# Altium schematic sheet busanalizer.SchDoc
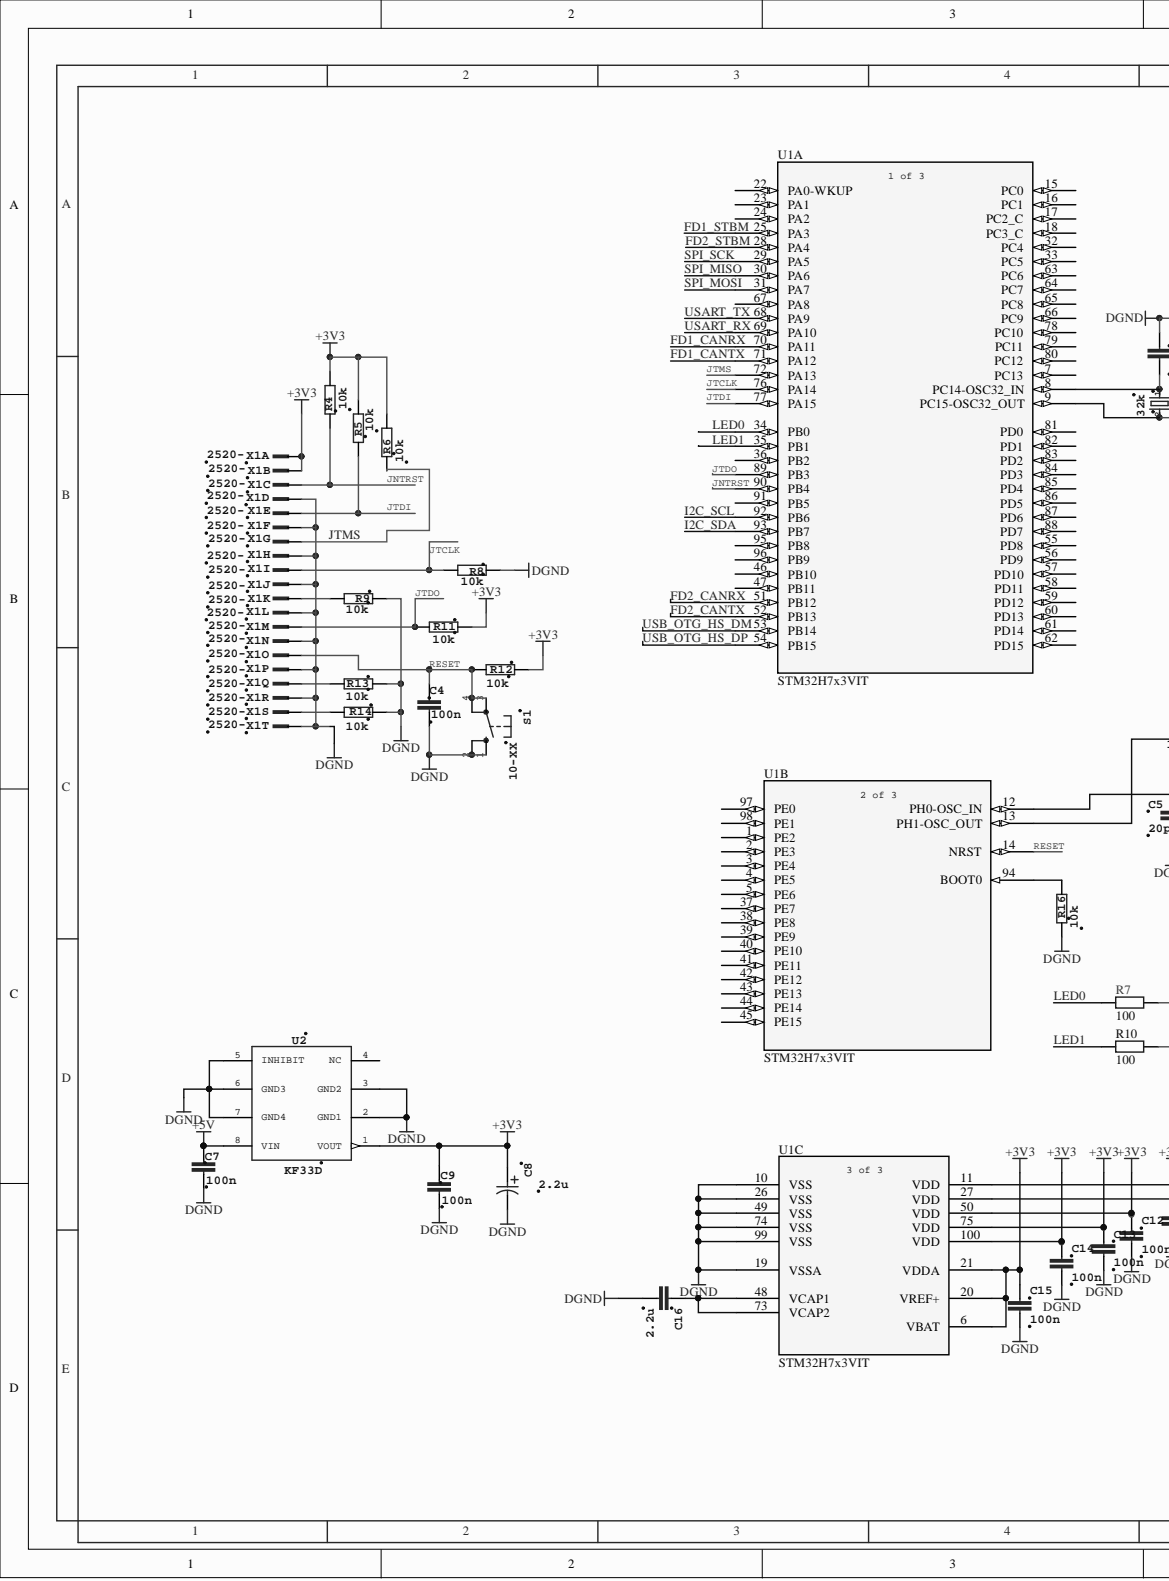
[diagram p0: busanalizer.SchDoc, left]
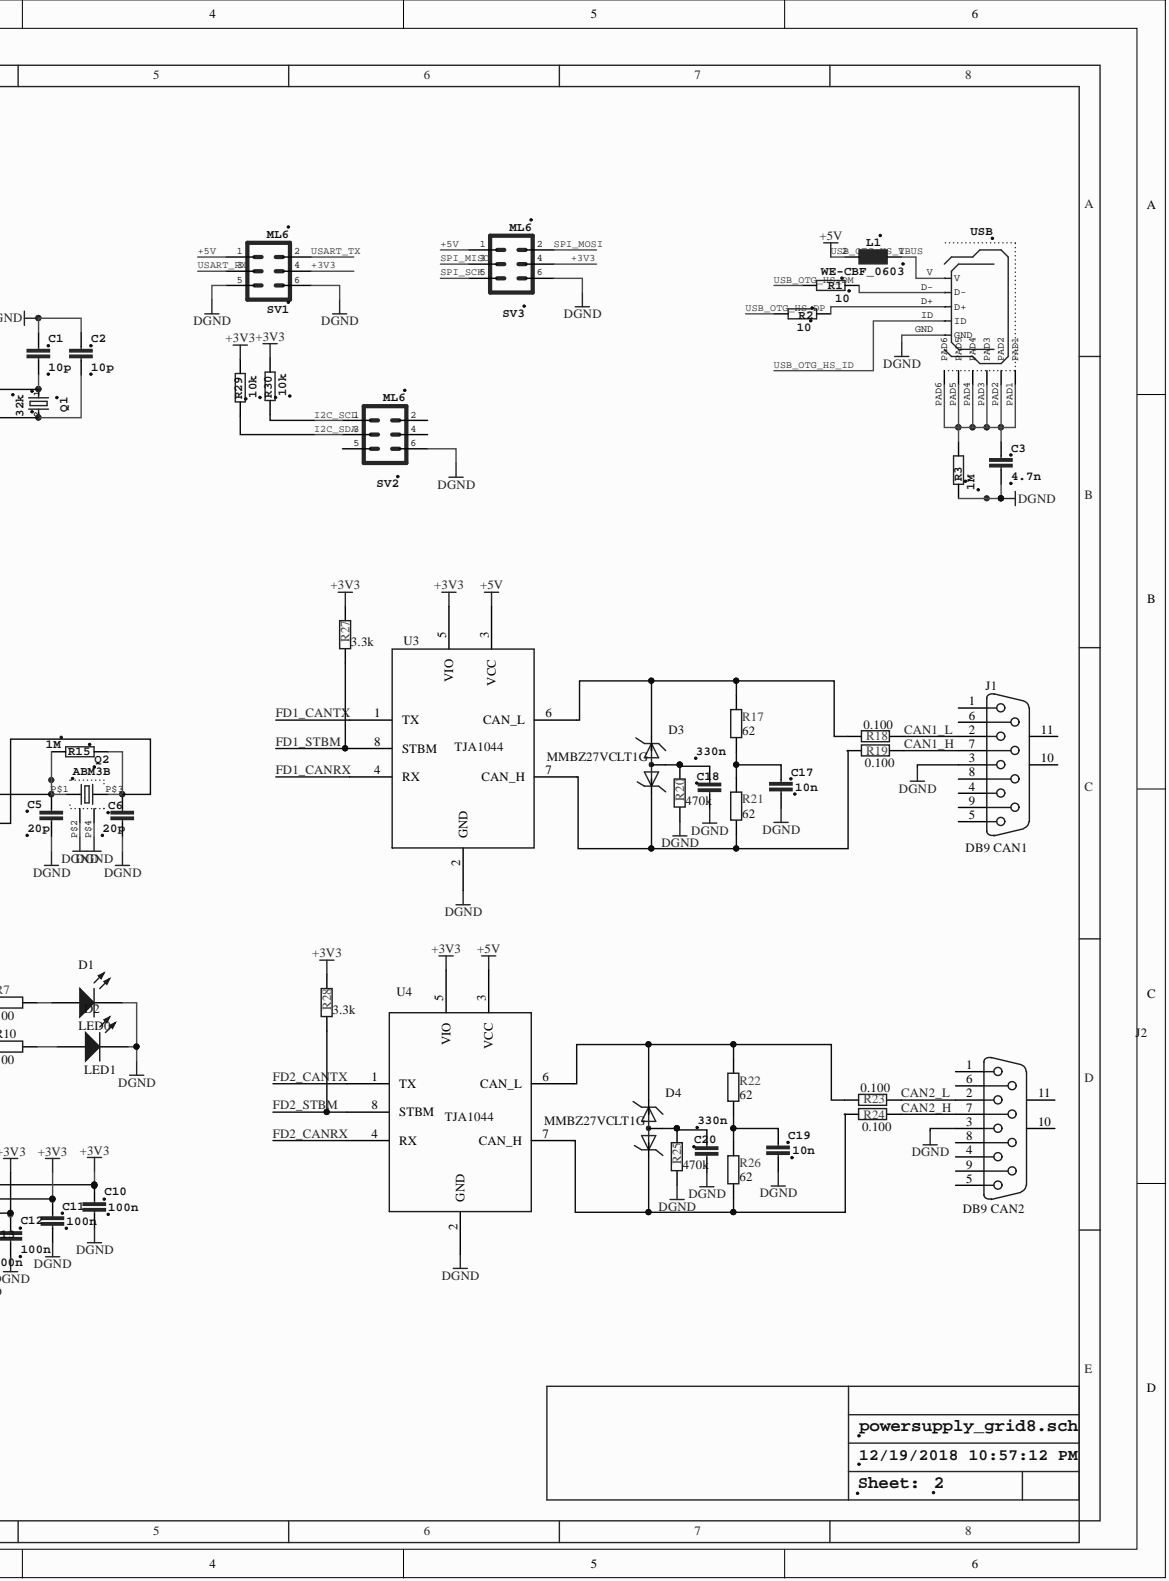
[diagram p1: busanalizer.SchDoc, right]

## Parts
C1  10p  [C0402]
C2  10p  [C0402]
C3  4.7n  [C0805]
C4  100n  [C0402]
C5  20p  [C0402]
C6  20p  [C0402]
C7  100n  [C0603]
C8  2.2u  [CAPAE430X610N]
C9  100n  [C0603]
C10  100n  [C0402]
C11  100n  [C0402]
C12  100n  [C0402]
C13  100n  [C0402]
C14  100n  [C0402]
C15  100n  [C0402]
C16  2.2u  [C0805]
C17  10n  [0603]
C18  330n  [0603]
C19  10n  [0603]
C20  330n  [0603]
D1  LED0  [LED-0]
D2  LED1  [LED-0]
D3  MMBZ27VCLT1G  [SOT23]
D4  MMBZ27VCLT1G  [SOT23]
J1  DB9 CAN1  [DSUB1.385-2H9]
J2  DB9 CAN2  [DSUB1.385-2H9]
L1  WE-CBF_0603  [0603]
Q1  32k  [TC26V]
Q2  ABM3B  [ABM3B]
R1  10  [R0805]
R2  10  [R0805]
R3  1M  [R0805]
R4  10k  [R0805]
R5  10k  [R0805]
R6  10k  [R0805]
R7  value 100  [6-0805_L]  (hidden comment: Res2)
R8  10k  [R0805]
R9  10k  [R0805]
R10  value 100  [6-0805_L]  (hidden comment: Res2)
R11  10k  [R0805]
R12  10k  [R0805]
R13  10k  [R0805]
R14  10k  [R0805]
R15  1M  [R0402]
R16  10k  [R0805]
R17  value 62  [6-0805_L]  (hidden comment: Res2)
R18  value 0.100  [6-0805_L]  (hidden comment: Res2)
R19  value 0.100  [6-0805_L]  (hidden comment: Res2)
R20  value 470k  [6-0805_L]  (hidden comment: Res2)
R21  value 62  [6-0805_L]  (hidden comment: Res2)
R22  value 62  [6-0805_L]  (hidden comment: Res2)
R23  value 0.100  [6-0805_L]  (hidden comment: Res2)
R24  value 0.100  [6-0805_L]  (hidden comment: Res2)
R25  value 470k  [6-0805_L]  (hidden comment: Res2)
R26  value 62  [6-0805_L]  (hidden comment: Res2)
R27  value 3.3k  [6-0805_L]  (hidden comment: Res2)
R28  value 3.3k  [6-0805_L]  (hidden comment: Res2)
R29  10k  [R0805]
R30  10k  [R0805]
S1  10-XX  [B3F-10XX]
SV1  ML6  [ML6]
SV2  ML6  [ML6]
SV3  ML6  [ML6]
U1  STM32H7x3VIT  [LQFP100-14x14mm]  (parts A,B,C)
U2  KF33D  [SO8]
U3  TJA1044  [SO8]
U4  TJA1044  [SO8]
USB  USB_MICROB  [USB_MICROB_PACKAGE]  (value not drawn)
X1  2520-  [PAK100/2500-20]  (parts A,B,C,D,E,F,G,H,I,J,K,L,M,N,O,P,Q,R,S,T)

## Nets
DGND: C1.1 C2.1 C3.2 C4.2 C5.2 C6.2 C7.2 C8.2 C9.2 C10.2 C11.2 C12.2 C13.2 C14.2 C15.2 C16.2 C17.2 C18.2 C19.2 C20.2 D1.2 D2.2 J1.3 J2.3 Q2.P$2 Q2.P$4 R3.1 R8.1 R9.1 R13.1 R14.1 R16.1 R20.1 R25.1 S1.1 S1.2 SV1.5 SV1.6 SV2.6 SV3.6 U1.10(VSS) U1.19(VSSA) U1.26(VSS) U1.49(VSS) U1.74(VSS) U1.99(VSS) U2.2(GND1) U2.3(GND2) U2.5(INHIBIT) U2.6(GND3) U2.7(GND4) U3.2(GND) U4.2(GND) USB.GND X1.4 X1.6 X1.8 X1.10 X1.12 X1.14 X1.16 X1.18 X1.20
+3V3: C8.1 C9.1 C10.1 C11.1 C12.1 C13.1 C14.1 C15.1 R4.2 R5.2 R6.2 R11.1 R12.1 R27.2 R28.2 R29.2 R30.2 SV1.4 SV3.4 U1.6(VBAT) U1.11(VDD) U1.20(VREF+) U1.21(VDDA) U1.27(VDD) U1.50(VDD) U1.75(VDD) U1.100(VDD) U2.1(VOUT) U3.5(VIO) U4.5(VIO) X1.1 X1.2
+5V: C7.1 L1.2 SV1.1 SV3.1 U2.8(VIN) U3.3(VCC) U4.3(VCC)
CAN1_H: J1.7 R19.2
CAN1_L: J1.2 R18.2
CAN2_H: J2.7 R24.2
CAN2_L: J2.2 R23.2
FD1_CANRX: U1.70(PA11) U3.4(RX)
FD1_CANTX: U1.71(PA12) U3.1(TX)
FD1_STBM: R27.1 U1.25(PA3) U3.8(STBM)
FD2_CANRX: U1.51(PB12) U4.4(RX)
FD2_CANTX: U1.52(PB13) U4.1(TX)
FD2_STBM: R28.1 U1.28(PA4) U4.8(STBM)
I2C_SCL: R30.1 SV2.1 U1.92(PB6)
I2C_SDA: R29.1 SV2.3 U1.93(PB7)
JNTRST: R4.1 U1.90(PB4) X1.3
JTCLK: R8.2 U1.76(PA14) X1.9
JTDI: R5.1 U1.77(PA15) X1.5
JTDO: R11.2 U1.89(PB3) X1.13
JTMS: R6.1 U1.72(PA13) X1.7
LED0: R7.1 U1.34(PB0)
LED1: R10.1 U1.35(PB1)
RESET: C4.1 R12.2 S1.3 S1.4 U1.14(NRST) X1.15
SPI_MISO: SV3.3 U1.30(PA6)
SPI_MOSI: SV3.2 U1.31(PA7)
SPI_SCK: SV3.5 U1.29(PA5)
USART_RX: SV1.3 U1.69(PA10)
USART_TX: SV1.2 U1.68(PA9)
USB_OTG_HS_DM: R1.2 U1.53(PB14)
USB_OTG_HS_DP: R2.2 U1.54(PB15)
USB_OTG_HS_ID: USB.ID
NetC1_2: C1.2 Q1.1 U1.8(PC14-OSC32_IN)
NetC2_2: C2.2 Q1.2 U1.9(PC15-OSC32_OUT)
NetC3_1: C3.1 R3.2 USB.PAD1 USB.PAD2 USB.PAD3 USB.PAD4 USB.PAD5 USB.PAD6
NetC5_1: C5.1 Q2.P$1 R15.2 U1.12(PH0-OSC_IN)
NetC6_1: C6.1 Q2.P$3 R15.1 U1.13(PH1-OSC_OUT)
NetC16_1: C16.1 U1.48(VCAP1) U1.73(VCAP2)
NetC17_1: C17.1 R17.1 R21.2
NetC18_1: C18.1 D3.3 R20.2
NetC19_1: C19.1 R22.1 R26.2
NetC20_1: C20.1 D4.3 R25.2
NetD1_1: D1.1 R7.2
NetD2_1: D2.1 R10.2
NetD3_1: D3.1 R17.2 R18.1 U3.6(CAN_L)
NetD3_2: D3.2 R19.1 R21.1 U3.7(CAN_H)
NetD4_1: D4.1 R22.2 R23.1 U4.6(CAN_L)
NetD4_2: D4.2 R24.1 R26.1 U4.7(CAN_H)
NetL1_1: L1.1 USB.V
NetR1_1: R1.1 USB.D-
NetR2_1: R2.1 USB.D+
NetR9_2: R9.2 X1.11
NetR13_2: R13.2 X1.17
NetR14_2: R14.2 X1.19
NetR16_2: R16.2 U1.94(BOOT0)

Not connected: J1 1 4 5 6 8 9 10 11; J2 1 4 5 6 8 9 10 11; SV2 2 4 5; U1 1(PE2) 2(PE3) 3(PE4) 4(PE5) 5(PE6) 7(PC13) 15(PC0) 16(PC1) 17(PC2_C) 18(PC3_C) 22(PA0-WKUP) 23(PA1) 24(PA2) 32(PC4) 33(PC5) 36(PB2) 37(PE7) 38(PE8) 39(PE9) 40(PE10) 41(PE11) 42(PE12) 43(PE13) 44(PE14) 45(PE15) 46(PB10) 47(PB11) 55(PD8) 56(PD9) 57(PD10) 58(PD11) 59(PD12) 60(PD13) 61(PD14) 62(PD15) 63(PC6) 64(PC7) 65(PC8) 66(PC9) 67(PA8) 78(PC10) 79(PC11) 80(PC12) 81(PD0) 82(PD1) 83(PD2) 84(PD3) 85(PD4) 86(PD5) 87(PD6) 88(PD7) 91(PB5) 95(PB8) 96(PB9) 97(PE0) 98(PE1); U2 4(NC)

## Library data of the parts
C1 C2 C4-C6 C10-C15 C17-C20 = C-EUC0402: <B>CAPACITOR</B>, European symbol; Component Kind: Standard; Library Name: rcl.IntLib; SPICEPREFIX: C
C3 = C-EUC0805: <B>CAPACITOR</B>, European symbol; Component Kind: Standard; Library Name: rcl.IntLib; SPICEPREFIX: C
C7 C9 = C-EUC0603: <B>CAPACITOR</B>, European symbol; Component Kind: Standard; Library Name: rcl.IntLib; SPICEPREFIX: C
C8 = EEE--CASE-B: MPN: EEE-HC1HR47R; MPN: EEE-HC1HR33R; MPN: EEE-HC1HR10R; MPN: EEE-HC1H3R3R; MPN: EEE-HC1H2R2R; MPN: EEE-HC1H1R0R; MPN: EEE-HC1C100R; MPN: EEE-HC0J220R; MPN: EEE-HB1H2R2R; MPN: EEE-HB1H1R0R; MPN: EEE-HB1E4R7R; MPN: EEE-HB1C100R; MPN: EEE-HB0J220R; MPN: EEE-HA1V4R7R; MPN: EEE-HA1V100WR; MPN: EEE-HA1HR47R; MPN: EEE-HA1HR33R; MPN: EEE-HA1HR22R; MPN: EEE-HA1HR10R; MPN: EEE-HA1H3R3R; MPN: EEE-HA1H2R2R; MPN: EEE-HA1H1R0R; MPN: EEE-HA1E4R7R; MPN: EEE-HA1E100WR; MPN: EEE-HA1C220WR; MPN: EEE-HA1C100R; MPN: EEE-HA1A330WR; MPN: EEE-HA1A220WR; MPN: EEE-HA0J470WR; MPN: EEE-HA0J330WR; MPN: EEE-HA0J220R; MPN: EEE-FTH100UAR; MPN: EEE-FT1V220AR; MPN: EEE-FT1E330AR; MPN: EEE-FT1E220AR; MPN: EEE-FT1C470AR; MPN: EEE-FT1A680AR; MPN: EEE-FT0J101AR; TOLERANCE: ±20 %; VOLTAGERATING: 50V; VOLTAGERATING: 16V; VOLTAGERATING: 6.3V; VOLTAGERATING: 25V; VOLTAGERATING: 35V; VOLTAGERATING: 10V; Component Kind: Standard; OC_FARNELL: 1714711; OC_FARNELL: 1714710; OC_FARNELL: 1714708; OC_FARNELL: 1714714; OC_FARNELL: 1714713; OC_FARNELL: 1714712; OC_FARNELL: 1714692; OC_FARNELL: 1714683; OC_FARNELL: 9696865; OC_FARNELL: 9696857; OC_FARNELL: 9696822; OC_FARNELL: 9696792; OC_FARNELL: 9696750; OC_FARNELL: 1973337; OC_FARNELL: 1973338; OC_FARNELL: 1973357; OC_FARNELL: 1973355; OC_FARNELL: 1973354; OC_FARNELL: 1973353; OC_FARNELL: 1973360; OC_FARNELL: 1973359; OC_FARNELL: 1973358; OC_FARNELL: 1973317; OC_FARNELL: 1973318; OC_FARNELL: 1973302; OC_FARNELL: 1973301; OC_FARNELL: 1973291; OC_FARNELL: 1973288; OC_FARNELL: 1973276; OC_FARNELL: 1973275; OC_FARNELL: 1973274; OC_FARNELL: 1868422; OC_FARNELL: 1868413; OC_FARNELL: 1868403; OC_FARNELL: 1868402; OC_FARNELL: 2065967; OC_FARNELL: 2065959; OC_FARNELL: 2065951; OC_NEWARK: 15R0920; OC_NEWARK: 15R0919; OC_NEWARK: 15R0917; OC_NEWARK: 15R0926; OC_NEWARK: 15R0924; OC_NEWARK: 15R0921; OC_NEWARK: 15R0908; OC_NEWARK: 15R0902; OC_NEWARK: 96K9421; OC_NEWARK: 96K9419; OC_NEWARK: 96K9418; OC_NEWARK: 96K9414; OC_NEWARK: 96K9411; OC_NEWARK: 58T9286; OC_NEWARK: 58T9275; OC_NEWARK: unknown; OC_NEWARK: 58T9251; OC_NEWARK: 58T9250; OC_NEWARK: 58T9249; OC_NEWARK: 58T9260; OC_NEWARK: 58T9257; OC_NEWARK: 58T9253; OC_NEWARK: 58T9245; OC_NEWARK: 58T9233; OC_NEWARK: 58T9220; OC_NEWARK: 58T9217; OC_NEWARK: 58T9213; OC_NEWARK: 58T9209; OC_NEWARK: 58T9204; OC_NEWARK: 58T9199; OC_NEWARK: 58T9198; OC_NEWARK: 31T8171; OC_NEWARK: 31T8187; OC_NEWARK: 53W8320; OC_NEWARK: 31T8175; OC_NEWARK: 73T9160; OC_NEWARK: 73T9155; OC_NEWARK: 73T9146; PACKAGE: CASE-B; SUPPLIER: PANASONIC; Library Name: Panasonic-EEEFT_EEEH.IntLib
C16 = C-EUC0805: Component Kind: Standard; Library Name: rcl.IntLib; SPICEPREFIX: C
D1 D2 = LED0: Typical INFRARED GaAs LED; Component Kind: Standard; LatestRevisionDate: 08-Jul-2005; LatestRevisionNote: Mech Layer 1 removed.; Library Name: Miscellaneous Devices.IntLib; PackageDescription: LED; 2 Leads; PackageReference: LED-0; Published: 8-Jun-2000; Simulation: LED0; Publisher: Altium Limited
J1 J2 = D Connector 9: Receptacle Assembly, 9 Position, Right Angle; PackageDocument: O; Positions: 9; Component Kind: Standard; DatasheetDocument: 1-Sep-1999; Gender: Receptacle; LatestRevisionDate: 17-Jul-2002; LatestRevisionNote: Re-released for DXP Platform.; Library Name: Miscellaneous Connectors.IntLib; Orientation: Right Angle; PackageReference: 788750; Published: 15-Jun-2001; Signal Integrity: Connector; Publisher: Altium Limited
L1 = WE-CBF_0603: <b> SMD EMI Suppression Ferrite Beads WE-CBF</b> <p>  - Excellent anti-EMI properties <br> - Low DC-restistance <br> - Impedances till 3000 ohms <br> - Rated current up to 6 A<p>  -- Reliable Ni-Sn electrodes<br> -- Perfect as data lined filter and for uncoupling of distribution voltage<br>; MPN: 742792695; Component Kind: Standard; Library Name: WE-CBF.IntLib; OC_FARNELL: 1635699; OC_NEWARK: 08P2449; PACKAGE: IND-0603; SUPPLIER: Wuerth Elektronik
Q1 = CRYSTALTC26V: <b>CRYSTAL</b>; 1: 1; 2: 2; Component Kind: Standard; Library Name: crystal.IntLib; OC_FARNELL: unknown; OC_NEWARK: unknown
R1-R6 R8 R9 R11-R14 R16 R29 R30 = R-EU_R0805: <B>RESISTOR</B>, European symbol; Component Kind: Standard; Library Name: rcl.IntLib
R7 R10 R17-R28 = Res2: Resistor; Component Kind: Standard; LatestRevisionDate: 17-Jul-2002; LatestRevisionNote: Re-released for DXP Platform.; Library Name: Miscellaneous Devices.IntLib; PackageDescription: Axial Device, Thru-Hole; 2 Leads; 0.4 in Pin Spacing; PackageReference: AXIAL-0.4; Published: 8-Jun-2000; Signal Integrity: Res; Simulation: RESISTOR; Publisher: Altium Limited
R15 = R-EU_R0402: <B>RESISTOR</B>, European symbol; Component Kind: Standard; Library Name: rcl.IntLib
S1 = 10-XX: <b>OMRON SWITCH</b>; MPN: B3F-1000; Component Kind: Standard; Library Name: switch-omron.IntLib; OC_FARNELL: 176432; OC_NEWARK: 36M3542
SV1-SV3 = ML6: <b>HARTING</b>; Component Kind: Standard; Library Name: con-harting-ml.IntLib; OC_FARNELL: unknown; OC_NEWARK: unknown
U1 = STM32H7x3VIT: Imported; Vendor: STMicroelectronics; Component Kind: Standard; Library Name: 2019-12-23_19-59-07.IntLib; Manufacturer_Part_Number: stm32h7x3vit; RefDes: RefDes; Type: DEV; Value: Value; Copyright: Copyright (C) 2016 Accelerated Designs. All rights reserved
USB = USB_MICROB: This is the hirose ZX62-B-5PA(11) MICRO-USB B Type female connection.; Component Kind: Standard; USB: USB; Library Name: usb_hinrose.IntLib
X1 = 2520-: <b>3M (TM) Pak 100 4-Wall Header</b><p> Source: 3M; Component Kind: Standard; Library Name: con-3m.IntLib; OC_FARNELL: unknown; OC_NEWARK: unknown
D3 D4 = MMBZ27VCLT1G: Component Kind: Standard; Library Name: MMBZ27VCLT1G.IntLib
Q2 = ABM3B: Component Kind: Standard; Library Name: crystal_my.IntLib
U2 = KF33D: Component Kind: Standard; Library Name: KF33BD.SCHLIB
U3 U4 = TJA1044: Component Kind: Standard; Library Name: TJA1044.IntLib
